# Altium schematic sheet 11_BTN_LED_GPIO.SchDoc
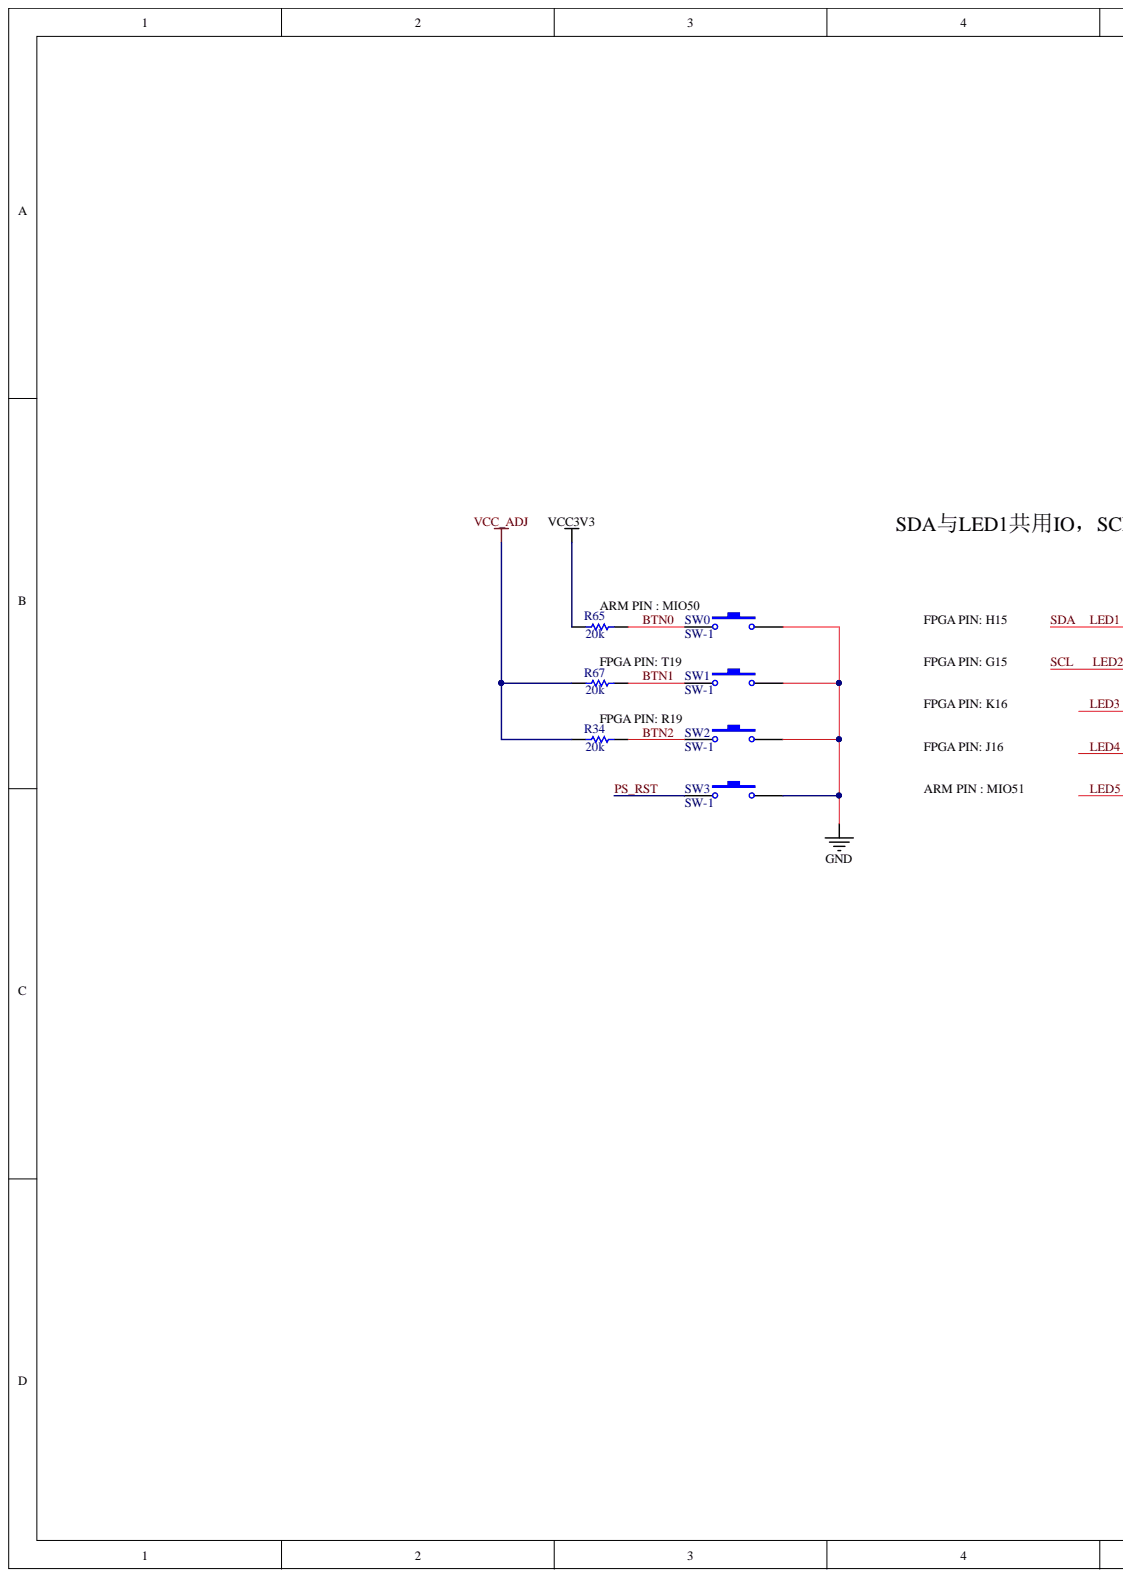
[diagram p0: 11_BTN_LED_GPIO.SchDoc, left]
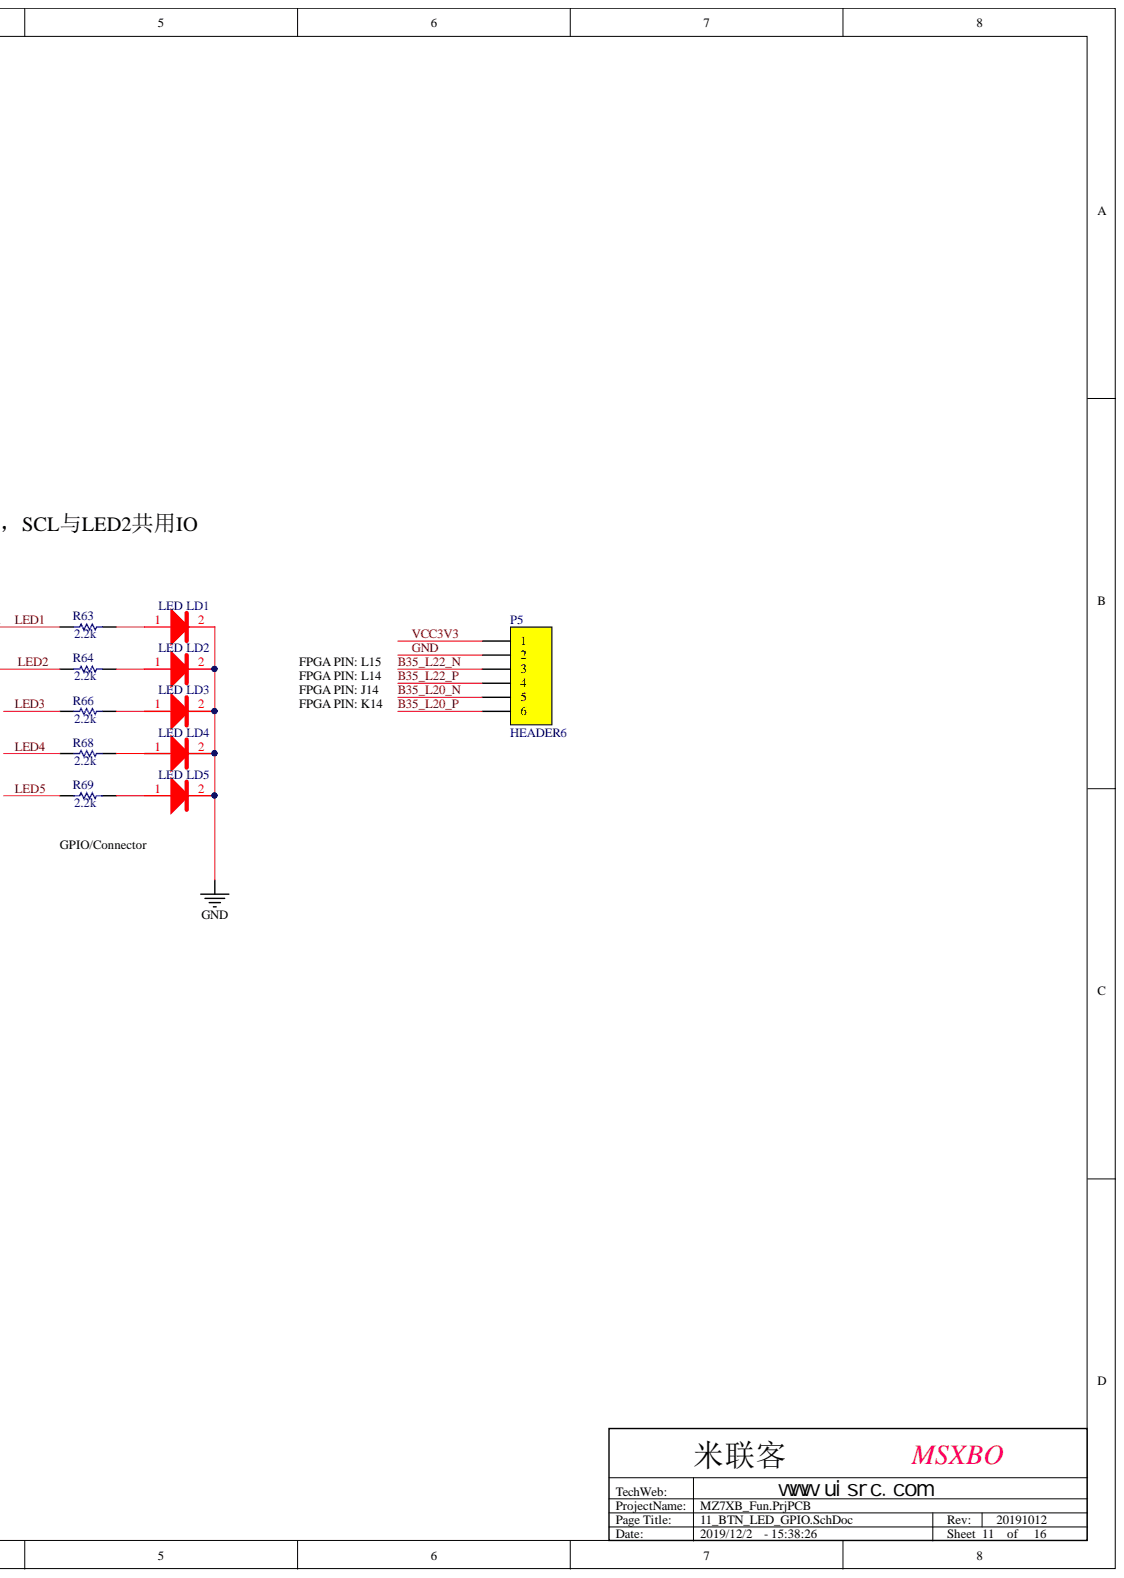
[diagram p1: 11_BTN_LED_GPIO.SchDoc, right]

## Parts
LD1  LED  [0603D]
LD2  LED  [0603D]
LD3  LED  [0603D]
LD4  LED  [0603D]
LD5  LED  [0603D]
P5  HEADER6  [HEADER1X6]
R34  20k  [RES0402]
R63  2.2k  [RES0402]
R64  2.2k  [RES0402]
R65  20k  [RES0402]
R66  2.2k  [RES0402]
R67  20k  [RES0402]
R68  2.2k  [RES0402]
R69  2.2k  [RES0402]
SW0  SW-1  [SW-3X9]
SW1  SW-1  [SW-3X9]
SW2  SW-1  [SW-3X9]
SW3  SW-1  [SW-3X9]

## Nets
VCC_ADJ: R34.2 R67.2
B35_L20_N: P5.5
B35_L20_P: P5.6
B35_L22_N: P5.3
B35_L22_P: P5.4
BTN0: R65.1 SW0.1
BTN1: R67.1 SW1.1
BTN2: R34.1 SW2.1
GND: LD1.2 LD2.2 LD3.2 LD4.2 LD5.2 P5.2 SW0.2 SW1.2 SW2.2 SW3.2
LED0: R68.2
LED1: R66.2
LED2: R64.2
LED3: R63.2
LED4: R69.2
PS_RST: SW3.1
VCC3V3: P5.1 R65.2
NetLD1_1: LD1.1 R63.1
NetLD2_1: LD2.1 R64.1
NetLD3_1: LD3.1 R66.1
NetLD4_1: LD4.1 R68.1
NetLD5_1: LD5.1 R69.1

## Library data of the parts
LD1-LD5 = LEDR: Component Kind: Standard; Library Name: jinrylib.IntLib
R34 R63-R69 = Res: 1K; Component Kind: Standard; Library Name: jinrylib.IntLib
SW0-SW3 = SW-1: SW; Component Kind: Standard; Library Name: jinrylib.IntLib
P5 = HEADER6: Component Kind: Standard; Library Name: jinrylib.IntLib
